# Altium schematic sheet Sheet4.SchDoc(Sheet4)
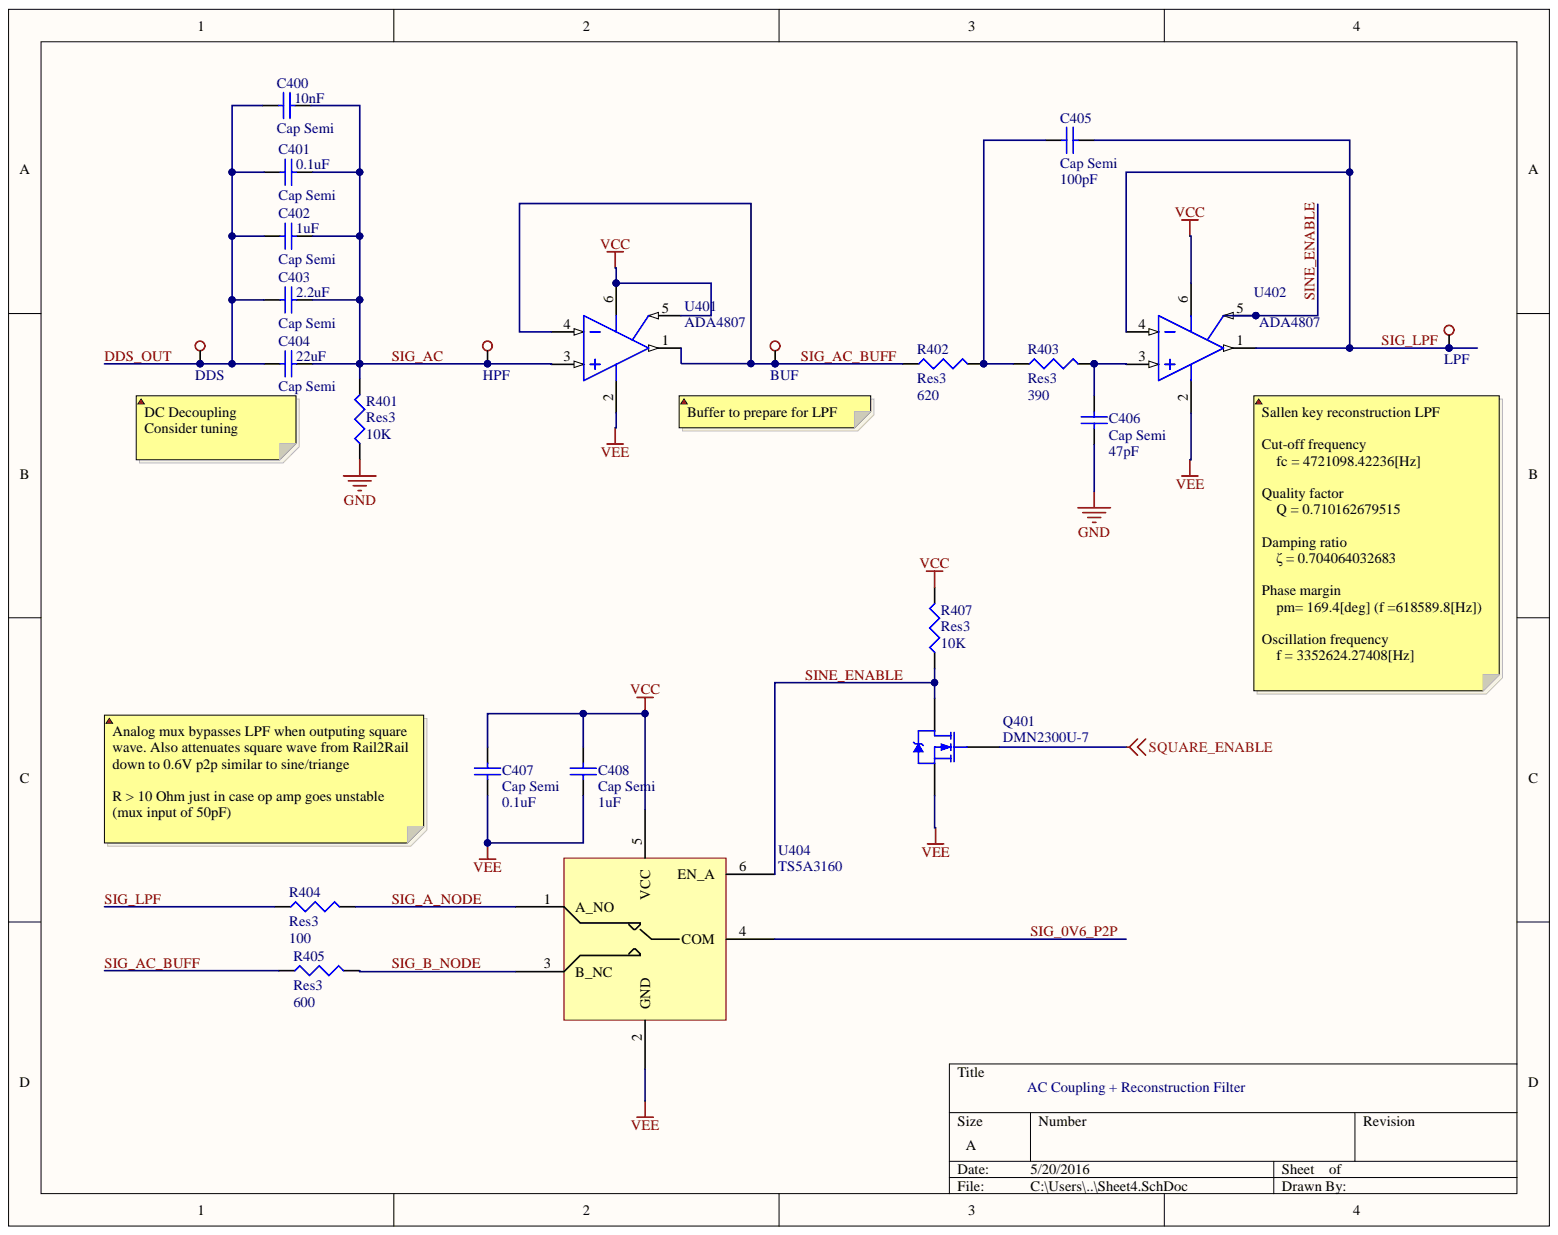
[diagram p0: Sheet4.SchDoc]

## Parts
BUF  TEST_POINT  [TEST_POINT]  (value not drawn)
C400  Cap Semi  value 10nF  [1608[0603]]
C401  Cap Semi  value 0.1uF  [1608[0603]]
C402  Cap Semi  value 1uF  [1608[0603]]
C403  Cap Semi  value 2.2uF  [1608[0603]]
C404  Cap Semi  value 22uF  [1608[0603]]
C405  Cap Semi  value 100pF  [1608[0603]]
C406  Cap Semi  value 47pF  [1608[0603]]
C407  Cap Semi  value 0.1uF  [1608[0603]]
C408  Cap Semi  value 1uF  [1608[0603]]
DDS  TEST_POINT  [TEST_POINT]  (value not drawn)
HPF  TEST_POINT  [TEST_POINT]  (value not drawn)
LPF  TEST_POINT  [TEST_POINT]  (value not drawn)
Q401  DMN2300U-7  [SOT-23_L]
R401  Res3  value 10K  [J1-0603]
R402  Res3  value 620  [J1-0603]
R403  Res3  value 390  [J1-0603]
R404  Res3  value 100  [J1-0603]
R405  Res3  value 600  [J1-0603]
R407  Res3  value 10K  [J1-0603]
U401  ADA4807  [SC70-5]
U402  ADA4807  [SC70-5]
U404  TS5A3160  [SOT26A-6AM]

## Nets
GND: C406.1 R401.1
SQUARE_ENABLE: Q401.1
VCC: C407.1 C408.1 R407.2 U401.5 U401.6 U402.6 U404.5(VCC)
VEE: C407.2 C408.2 Q401.2 U401.2 U402.2 U404.2(GND)
DDS_OUT: C400.1 C401.1 C402.1 C403.1 C404.1 DDS.0
SIG_0V6_P2P: U404.4(COM)
SIG_A_NODE: R404.2 U404.1(A_NO)
SIG_AC: C400.2 C401.2 C402.2 C403.2 C404.2 HPF.0 R401.2 U401.3
SIG_AC_BUFF: BUF.0 R402.1 R405.1 U401.1 U401.4
SIG_B_NODE: R405.2 U404.3(B_NC)
SIG_LPF: C405.2 LPF.0 R404.1 U402.1 U402.4
SINE_ENABLE: Q401.3 R407.1 U402.5 U404.6(EN_A)
NetC405_1: C405.1 R402.2 R403.1
NetC406_2: C406.2 R403.2 U402.3

## Library data of the parts
C400-C408 = Cap Semi: Capacitor (Semiconductor SIM Model); Component Kind: Standard; LatestRevisionDate: 29-May-2009; LatestRevisionNote: IPC-7351 Footprint Added.; Library Name: Miscellaneous Devices.IntLib; PackageDescription: Chip Capacitor; PackageReference: C1206; Published: 8-Jun-2000; Signal Integrity: Cap Semi; Simulation: CAP; Publisher: Altium Limited
R401-R403 R405 R407 = Res3: Resistor; Component Kind: Standard; LatestRevisionDate: 29-May-2009; LatestRevisionNote: IPC-7351 Footprint Added.; Library Name: Miscellaneous Devices.IntLib; PackageDescription: Chip Resistor; PackageReference: J1-0603; Published: 8-Jun-2000; Signal Integrity: Res; Simulation: RESISTOR; Publisher: Altium Limited
R404 = Res3: Resistor; VALUE: 100; Component Kind: Standard; LatestRevisionDate: 29-May-2009; LatestRevisionNote: IPC-7351 Footprint Added.; Library Name: Miscellaneous Devices.IntLib; PackageDescription: Chip Resistor; PackageReference: J1-0603; Published: 8-Jun-2000; Signal Integrity: Res; Simulation: RESISTOR; Publisher: Altium Limited
BUF DDS HPF LPF = TEST_POINT: Component Kind: Standard; Library Name: Altium.SchLib; Signal Integrity: Guessed Model
Q401 = DMN2300U-7: Component Kind: Standard; Library Name: Altium.SchLib; Signal Integrity: Guessed Model
U401 U402 = ADA4807: Component Kind: Standard; Library Name: Altium.SchLib; Signal Integrity: Guessed Model
U404 = TS5A3160: Component Kind: Standard; Library Name: Altium.SchLib; Signal Integrity: Guessed Model
